# Altium schematic sheet power.SchDoc(power)
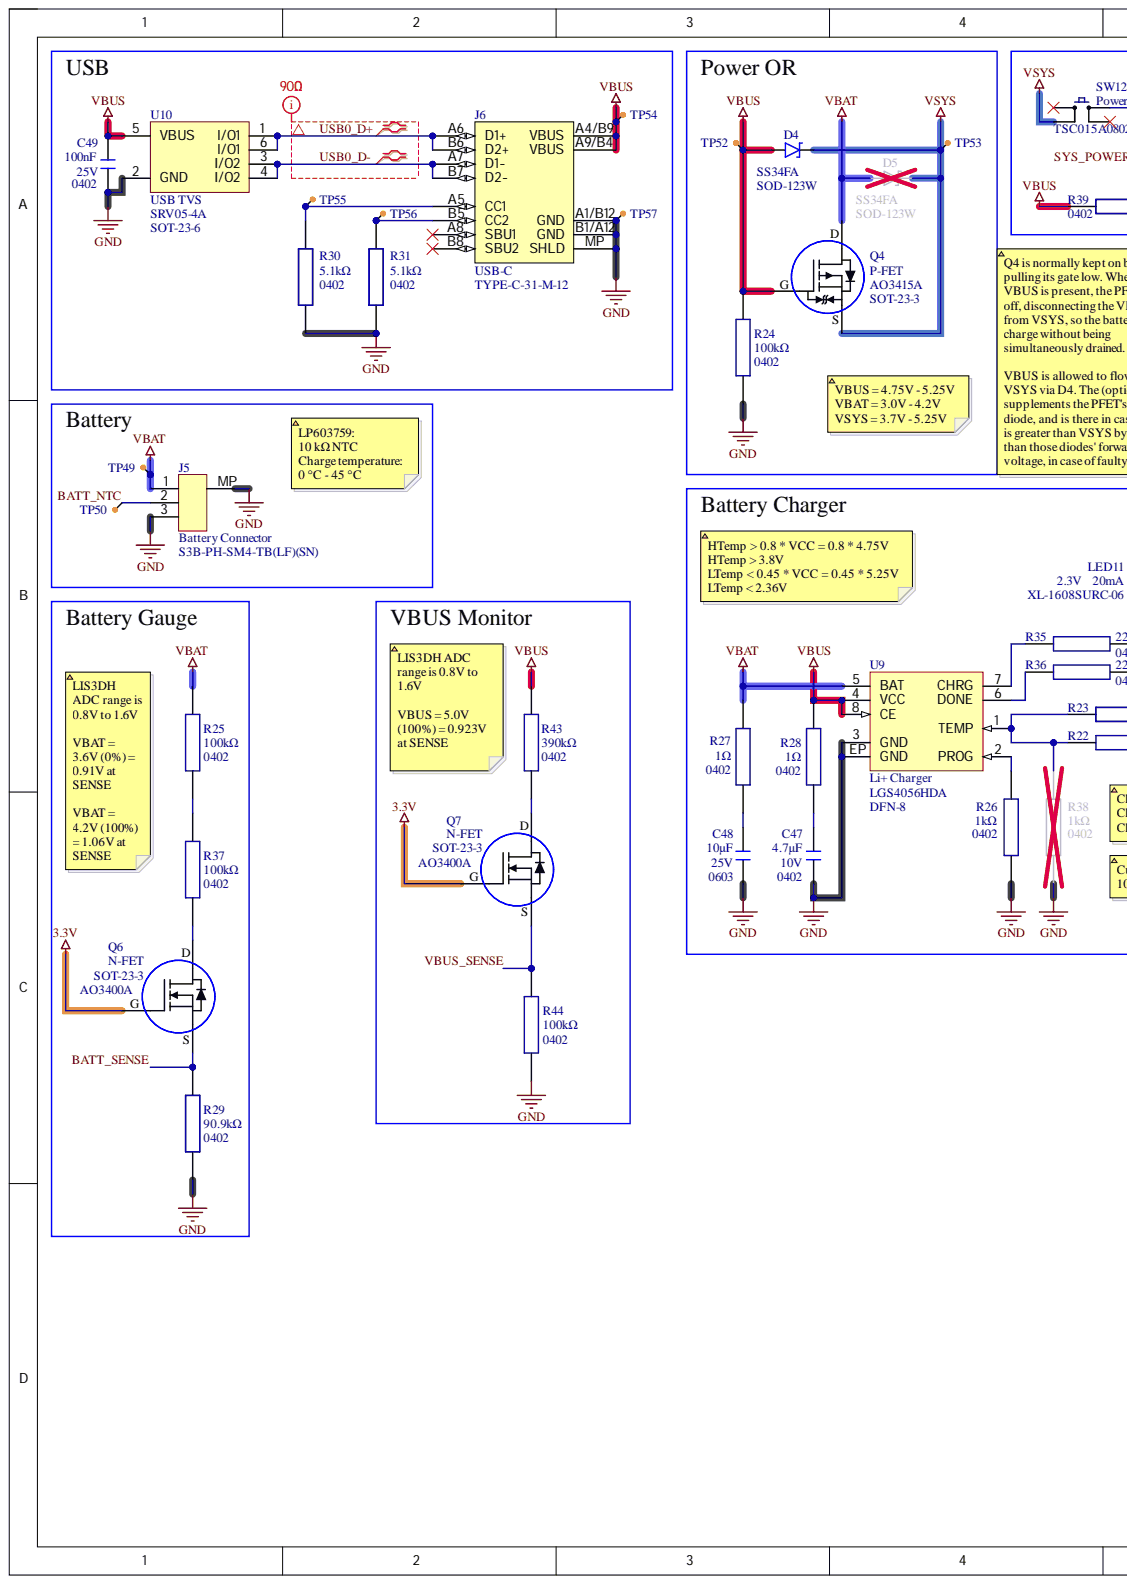
[diagram p0: power.SchDoc, left]
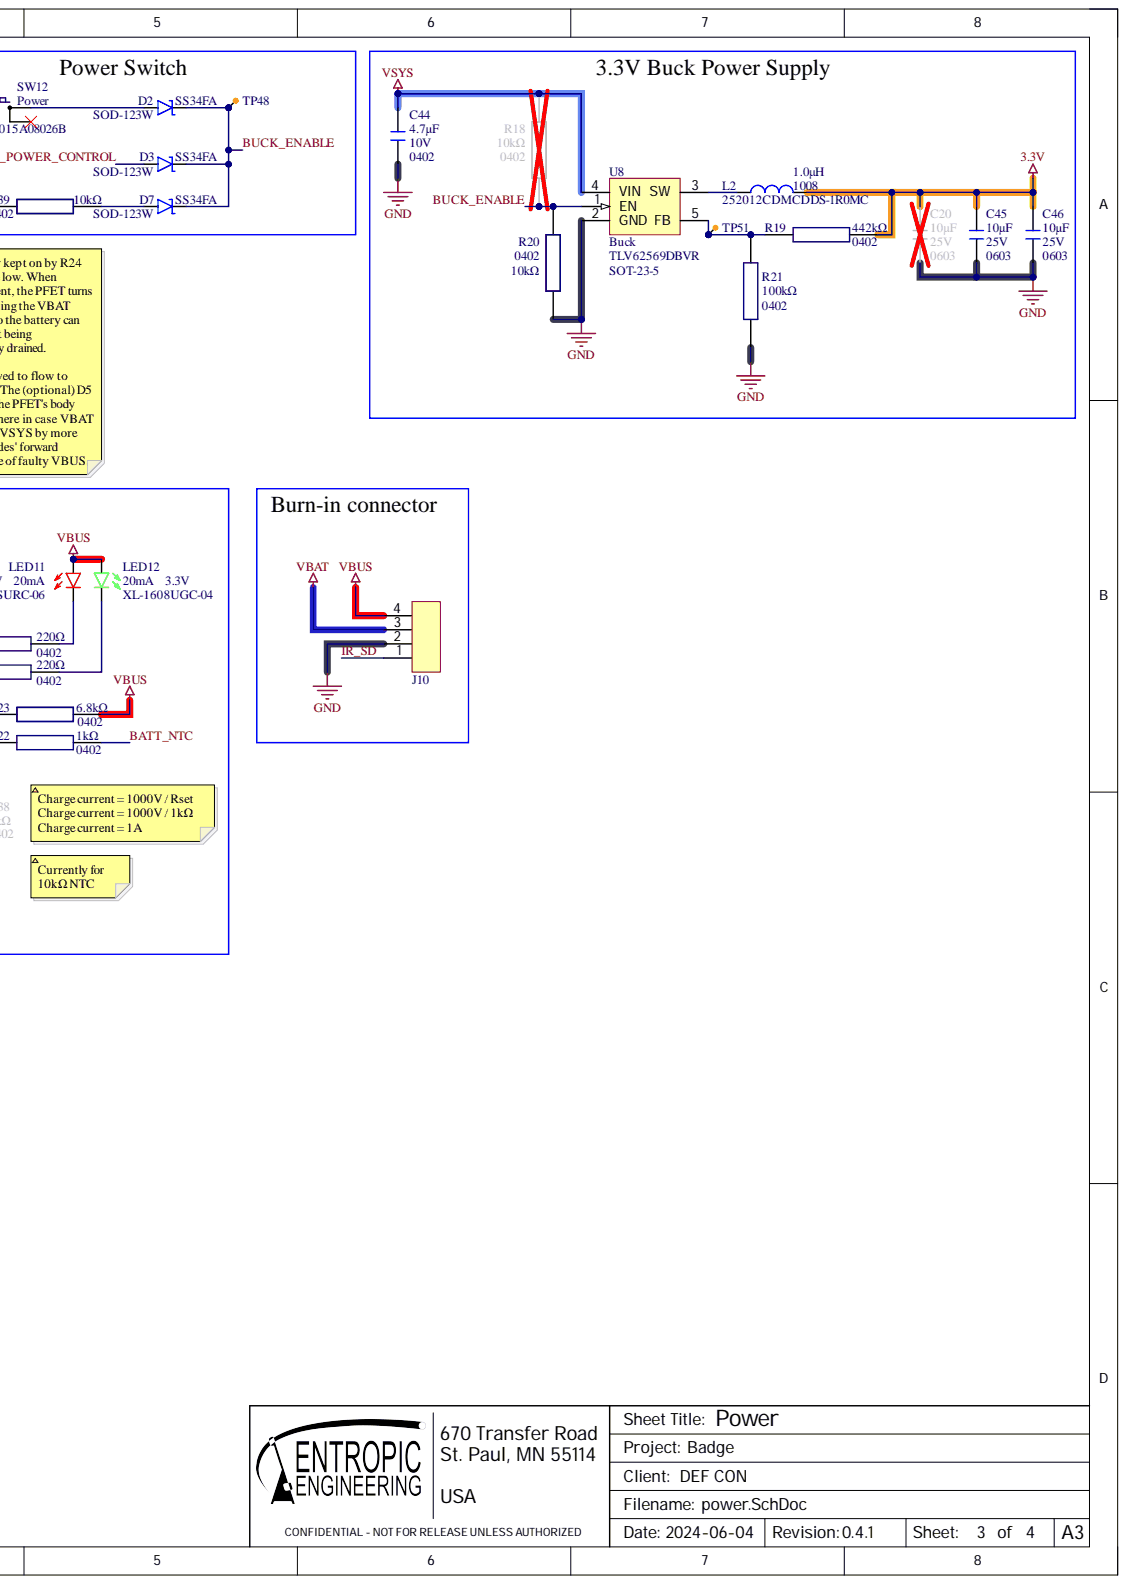
[diagram p1: power.SchDoc, right]

## Parts
C20  value 10µF  Case Code (Imperial): 0603  Voltage Rating: 25V  [0603_capacitor]  (hidden comment: CL10A106MA8NRNC)
C44  value 4.7µF  Case Code (Imperial): 0402  Voltage Rating: 10V  [0402_capacitor]  (hidden comment: CL05A475KP5NRNC)
C45  value 10µF  Case Code (Imperial): 0603  Voltage Rating: 25V  [0603_capacitor]  (hidden comment: CL10A106MA8NRNC)
C46  value 10µF  Case Code (Imperial): 0603  Voltage Rating: 25V  [0603_capacitor]  (hidden comment: CL10A106MA8NRNC)
C47  value 4.7µF  Case Code (Imperial): 0402  Voltage Rating: 10V  [0402_capacitor]  (hidden comment: CL05A475KP5NRNC)
C48  value 10µF  Case Code (Imperial): 0603  Voltage Rating: 25V  [0603_capacitor]  (hidden comment: CL10A106MA8NRNC)
C49  Capacitance: 100nF  Case Code (Imperial): 0402  Voltage: 25V  [0402_capacitor]  (hidden comment: GRM155R71E104KE14D)
D2  SS34FA  Case/Package: SOD-123W  [SOD-123]
D3  SS34FA  Case/Package: SOD-123W  [SOD-123]
D4  SS34FA  Case/Package: SOD-123W  [SOD-123]
D5  SS34FA  [SOD-123]
D7  SS34FA  Case/Package: SOD-123W  [SOD-123]
J5  Battery Connector  [S3B-PH-SM4-TB]
J6  USB-C  Manufacturer Part Number: TYPE-C-31-M-12  [USB_C_2.0_M12]
J10  BURN_IN  [BURN_IN_PADS]  (value not drawn)  (no BOM)
L2  252012CDMCDDS-1R0MC  value 1.0μH  Package: 1008  [INDC2520X12N]
LED11  XL-1608SURC-06  Current: 20mA  [0603_DIODE]
LED12  XL-1608UGC-04  Current: 20mA  [0603_DIODE]
Q4  P-FET  Case/Package: SOT-23-3  [SOT95P280X115-3N]
Q6  N-FET  Case/Package: SOT-23-3  [SOT95P280X115-3N]
Q7  N-FET  Case/Package: SOT-23-3  [SOT95P280X115-3N]
R18  value 10kΩ  Case Code (Imperial): 0402  [0402_resistor]  (hidden comment: RC0402FR-0710KL)
R19  value 442kΩ  Case Code (Imperial): 0402  [0402_resistor]  (hidden comment: RC0402FR-07442KL)
R20  value 10kΩ  Case Code (Imperial): 0402  [0402_resistor]  (hidden comment: RC0402FR-0710KL)
R21  value 100kΩ  Case Code (Imperial): 0402  [0402_resistor]  (hidden comment: RC0402FR-07100KL)
R22  value 1kΩ  Case Code (Imperial): 0402  [0402_resistor]  (hidden comment: RC0402JR-071KL)
R23  value 6.8kΩ  Case Code (Imperial): 0402  [0402_resistor]  (hidden comment: RC0402FR-076K8L)
R24  value 100kΩ  Case Code (Imperial): 0402  [0402_resistor]  (hidden comment: RC0402FR-07100KL)
R25  value 100kΩ  Case Code (Imperial): 0402  [0402_resistor]  (hidden comment: RC0402FR-07100KL)
R26  value 1kΩ  Case Code (Imperial): 0402  [0402_resistor]  (hidden comment: Rset)
R27  value 1Ω  Case/Package: 0402  [0402_resistor]  (hidden comment: 0402WGF100KTCE)
R28  value 1Ω  Case/Package: 0402  [0402_resistor]  (hidden comment: 0402WGF100KTCE)
R29  value 90.9kΩ  Case/Package: 0402  [0402_resistor]  (hidden comment: RC0402FR-0790K9L)
R30  value 5.1kΩ  Case Code (Imperial): 0402  [0402_resistor]  (hidden comment: RC0402FR-075K1L)
R31  value 5.1kΩ  Case Code (Imperial): 0402  [0402_resistor]  (hidden comment: RC0402FR-075K1L)
R35  value 220Ω  Case Code (Imperial): 0402  [0402_resistor]  (hidden comment: RC0402FR-07220RL)
R36  value 220Ω  Case Code (Imperial): 0402  [0402_resistor]  (hidden comment: RC0402FR-07220RL)
R37  value 100kΩ  Case Code (Imperial): 0402  [0402_resistor]  (hidden comment: RC0402FR-07100KL)
R38  value 1kΩ  Case Code (Imperial): 0402  [0402_resistor]  (hidden comment: Rset)
R39  value 10kΩ  Case Code (Imperial): 0402  [0402_resistor]  (hidden comment: RC0402FR-0710KL)
R43  value 390kΩ  Case/Package: 0402  [0402_resistor]  (hidden comment: RC0402FR-07390KL)
R44  value 100kΩ  Case Code (Imperial): 0402  [0402_resistor]  (hidden comment: RC0402FR-07100KL)
SW12  Power  [TSC015A08026B]
TP48  test_point-1  [test_point-1]  (value not drawn)  (no BOM)
TP49  test_point-1  [test_point-1]  (value not drawn)  (no BOM)
TP50  test_point-1  [test_point-1]  (value not drawn)  (no BOM)
TP51  test_point-1  [test_point-1]  (value not drawn)  (no BOM)
TP52  test_point-1  [test_point-1]  (value not drawn)  (no BOM)
TP53  test_point-1  [test_point-1]  (value not drawn)  (no BOM)
TP54  test_point-1  [test_point-1]  (value not drawn)  (no BOM)
TP55  test_point-1  [test_point-1]  (value not drawn)  (no BOM)
TP56  test_point-1  [test_point-1]  (value not drawn)  (no BOM)
TP57  test_point-1  [test_point-1]  (value not drawn)  (no BOM)
U8  Buck  Case/Package: SOT-23-5  [SOT95P280X115-5N]
U9  Li+ Charger  house_part_number: LGS4056HDA  Package: DFN-8  [SON50P200X80_HS-9N]
U10  USB TVS  Case/Package: SOT-23-6  Series: USB  [SOT95P280X110-6N]

## Nets
3.3V: C20.2 C45.2 C46.2 L2.2 Q6.1 Q7.1 R19.2
GND: C20.1 C44.1 C45.1 C46.1 C47.1 C48.1 C49.1 J5.3 J5.MP J6.A1/B12(GND) J6.B1/A12(GND) J6.MP(SHLD) J10.2 R20.1 R21.1 R24.1 R26.1 R29.2 R30.1 R31.1 R38.1 R44.1 TP57.1 U8.2(GND) U9.3(GND) U9.EP(GND) U10.2(GND)
VBAT: D5.A J5.1 J10.3 Q4.3 R25.2 R27.2 TP49.1 U9.5(BAT)
VBUS: C49.2 D4.A J6.A4/B9(VBUS) J6.A9/B4(VBUS) J10.4 LED11.2 LED12.2 Q4.1 R23.1 R24.2 R28.2 R39.2 R43.2 TP52.1 TP54.1 U9.4(VCC) U9.8(CE) U10.5(VBUS)
VSYS: C44.2 D4.K D5.K Q4.2 R18.2 SW12.2 TP53.1 U8.4(VIN)
BATT_NTC: J5.2 R22.1 TP50.1
BATT_SENSE: Q6.2 R29.1
BUCK_ENABLE: D2.K D3.K D7.K R18.1 R20.2 TP48.1 U8.1(EN)
IR_SD: J10.1
SYS_POWER_CONTROL: D3.A
USB0_D+: J6.A6(D1+) J6.B6(D2+) U10.1(I/O1) U10.6(I/O1)
USB0_D-: J6.A7(D1-) J6.B7(D2-) U10.3(I/O2) U10.4(I/O2)
VBUS_SENSE: Q7.2 R44.2
NetC47_2: C47.2 R28.1
NetC48_2: C48.2 R27.1
NetD2_A: D2.A SW12.3
NetD7_A: D7.A R39.1
NetJ6_A5: J6.A5(CC1) R30.2 TP55.1
NetJ6_B5: J6.B5(CC2) R31.2 TP56.1
NetL2_1: L2.1 U8.3(SW)
NetLED11_1: LED11.1 R35.1
NetLED12_1: LED12.1 R36.1
NetQ6_3: Q6.3 R37.1
NetQ7_3: Q7.3 R43.1
NetR19_1: R19.1 R21.2 TP51.1 U8.5(FB)
NetR22_2: R22.2 R23.2 R38.2 U9.1(TEMP)
NetR25_1: R25.1 R37.2
NetR26_2: R26.2 U9.2(PROG)
NetR35_2: R35.2 U9.7(CHRG)
NetR36_2: R36.2 U9.6(DONE)

Not connected: J6 A8(SBU1) B8(SBU2); SW12 1 4

## Library data of the parts
C20 C45 C46 C48 = CL10A106MA8NRNC: 10 µF, 25 V, X5R, 0603 Chip capacitor; house_part_number: C-10uF-0603-002; Manufacturer: Samsung; Material: 4241ConductorMaterial; Tolerance: 20%; Case Code (Metric): 1608; Case/Package: 0603; Category: Ceramic Capacitors; Component Kind: Standard; ComponentLink1Description: https://datasheet.ciiva.com/25766/cl10a106ma8nrnc-25766562.pdf?src-supplier=Digikey; ComponentLink1URL: https://datasheet.ciiva.com/25766/cl10a106ma8nrnc-25766562.pdf?src-supplier=Digikey; Height: 900um; Lead Free: Lead Free; Manufacturer Part Number: CL10A106MA8NRNC; Max Operating Temperature: 85°C; Min Operating Temperature: -55°C; Mounting Technology: Surface Mount; Packaging: Cut Tape; Pricing: 4000=0.0511, 8000=0.046, 12000=0.0434, 28000=0.0413, 100000=0.0356 (USD); RoHS: Yes; RoHS Compliant: Yes; Series: CL; Stock: 632000; Supplier 1: Arrow Electronics; Supplier Part Number 1: CL10A106MA8NRNC; Library Name: defcon_badge.SchLib
C44 C47 = CL05A475KP5NRNC: 4.7 µF, 10 V, X5R, 0402 Chip capacitor; house_part_number: C-4.7uF-0402-002; Manufacturer: Samsung; Material: Ceramic; Tolerance: 10%; Case Code (Metric): 1005; Case/Package: 0402; Category: Ceramic Capacitors; Component Kind: Standard; ComponentLink1Description: https://datasheet.ciiva.com/25724/cl05a475kp5nrnc-25724404.pdf?src-supplier=Digikey; ComponentLink1URL: https://datasheet.ciiva.com/25724/cl05a475kp5nrnc-25724404.pdf?src-supplier=Digikey; ComponentLink2Description: https://datasheet.ciiva.com/29198/458873-da-01-en-vs-kondensator-0402-29198304.pdf?src-supplier=Conrad; ComponentLink2URL: https://datasheet.ciiva.com/29198/458873-da-01-en-vs-kondensator-0402-29198304.pdf?src-supplier=Conrad; Construction: Flat; Depth: 0.5mm; Dielectric: X5R; Height: 0.5mm; Lead Free: Lead Free; Length: 1mm; Manufacturer Part Number: CL05A475KP5NRNC; Max Operating Temperature: 85°C; Min Operating Temperature: -55°C; Mounting Technology: Surface Mount; Package Quantity: 1; Packaging: Tape and Reel; Pricing: 1=0.28, 10=0.184, 50=0.129, 100=0.1042, 500=0.0774, 1000=0.06772, 2500=0.0645, 5000=0.05966 (USD); Radiation Hardening: No; RoHS: Yes; RoHS Compliant: Yes; Series: CL; Stock: 606274; Supplier 1: Digikey; Supplier Part Number 1: 1276-1480-1-ND; Thickness: 0.022inch; Width: 0.02inch; Library Name: defcon_badge.SchLib
C49 = GRM155R71E104KE14D: 100 nF, 25 V, X7R, 0402 Chip capacitor; house_part_number: C-100nF-0402-002; Manufacturer: Murata; Dielectric Material: Ceramic; Tolerance: 10%; Case Code (Metric): 1005; Case/Package: 0402; Category: Ceramic Capacitors; Component Kind: Standard; ComponentLink1Description: http://www.farnell.com/datasheets/1872206.pdf?src-supplier=Farnell; ComponentLink1URL: http://www.farnell.com/datasheets/1872206.pdf?src-supplier=Farnell; ComponentLink2Description: http://components.arrow.com/part/dataview/0323_06361249?src-supplier=Arrow+Europe; ComponentLink2URL: http://components.arrow.com/part/dataview/0323_06361249?src-supplier=Arrow+Europe; ComponentLink3Description: http://uk.rs-online.com/webdocs/144c/0900766b8144c113.pdf?src-supplier=RSComponents; ComponentLink3URL: http://uk.rs-online.com/webdocs/144c/0900766b8144c113.pdf?src-supplier=RSComponents; ComponentLink4Description: http://www.farnell.com/datasheets/2048008.pdf?src-supplier=Farnell; ComponentLink4URL: http://www.farnell.com/datasheets/2048008.pdf?src-supplier=Farnell; ComponentLink5Description: http://www.mouser.com/ds/2/281/c02e-2905.pdf?src-supplier=Mouser; ComponentLink5URL: http://www.mouser.com/ds/2/281/c02e-2905.pdf?src-supplier=Mouser; ComponentLink6Description: http://www.farnell.com/datasheets/2048008.pdf?src-supplier=Newark; ComponentLink6URL: http://www.farnell.com/datasheets/2048008.pdf?src-supplier=Newark; ComponentLink7Description: https://search.murata.co.jp/Ceramy/image/img/A01X/G101/ENG/GRM155R71E104KE14-01.pdf?src-supplier=Digi-Key; ComponentLink7URL: https://search.murata.co.jp/Ceramy/image/img/A01X/G101/ENG/GRM155R71E104KE14-01.pdf?src-supplier=Digi-Key; ComponentLink8Description: http://www.farnell.com/datasheets/2048008.pdf?src-supplier=Element14; ComponentLink8URL: http://www.farnell.com/datasheets/2048008.pdf?src-supplier=Element14; ComponentLink9Description: http://psearch.en.murata.com/capacitor/product/GRM155R71E104KE14%23.pdf?src-supplier=Avnet; ComponentLink9URL: http://psearch.en.murata.com/capacitor/product/GRM155R71E104KE14%23.pdf?src-supplier=Avnet; Depth: 0.5mm; Dielectric: X7R; Height: 0.5mm; Lead Free: Lead Free; Length: 1mm; Manufacturer Part Number: GRM155R71E104KE14D; Max Operating Temperature: 125°C; Min Operating Temperature: -55°C; Mount: Surface Mount; Package Quantity: 10000; Packaging: Tape and Reel; Pricing 1: 1=0.10000, 10=0.02700, 50=0.01460, 100=0.01190, 500=0.00848, 1000=0.00666, 2500=0.00605, 5000=0.00557 (USD); REACH SVHC: Unknown; Resistor Type: Ceramic; RoHS: Yes; RoHS Compliant: Yes; Supplier 1: Digi-Key; Supplier Part Number 1: 490-10698-1-ND; Termination: SMD/SMT; Thickness: 0.022inch; Voltage Rating: 25V; Voltage Rating (DC): 25V; Weight: 0.000212oz; Width: 0.02inch; Library Name: defcon_badge.SchLib
D2-D5 D7 = SS34FA: 40 V, 3 A Schottky Rectifier, SOD-123FA; house_part_number: D-SCHOTTKY-3A-SOD123F-002; Manufacturer: ON Semiconductor / Fairchild; Average Rectified Current: 3A; Element Configuration: Single; Max Forward Surge Current (Ifsm): 80A; Max Repetitive Reverse Voltage (Vrrm): 40V; Category: Schottky Diodes; Component Kind: Standard; ComponentLink1Description: http://www.farnell.com/datasheets/2552647.pdf?src-supplier=Farnell; ComponentLink1URL: http://www.farnell.com/datasheets/2552647.pdf?src-supplier=Farnell; ComponentLink2Description: http://www.mouser.com/ds/2/149/SS34FA-1012641.pdf?src-supplier=Mouser; ComponentLink2URL: http://www.mouser.com/ds/2/149/SS34FA-1012641.pdf?src-supplier=Mouser; ComponentLink3Description: http://www.farnell.com/datasheets/2552647.pdf?src-supplier=Element14; ComponentLink3URL: http://www.farnell.com/datasheets/2552647.pdf?src-supplier=Element14; ComponentLink4Description: http://www.farnell.com/datasheets/2552647.pdf?src-supplier=Newark; ComponentLink4URL: http://www.farnell.com/datasheets/2552647.pdf?src-supplier=Newark; ComponentLink5Description: https://www.fairchildsemi.com/datasheets/SS/SS34FA.pdf?src-supplier=Digikey; ComponentLink5URL: https://www.fairchildsemi.com/datasheets/SS/SS34FA.pdf?src-supplier=Digikey; ComponentLink6Description: https://www.onsemi.com/pub/Collateral/SS36FA-D.PDF?src-supplier=Digikey; ComponentLink6URL: https://www.onsemi.com/pub/Collateral/SS36FA-D.PDF?src-supplier=Digikey; ComponentLink7Description: https://datasheet.octopart.com/SS34FA-ON-Semiconductor-datasheet-137713515.pdf?src-supplier=TME; ComponentLink7URL: https://datasheet.octopart.com/SS34FA-ON-Semiconductor-datasheet-137713515.pdf?src-supplier=TME; Manufacturer Part Number: SS34FA; Max Reverse Voltage (DC): 40V; Mounting Technology: Surface Mount; Packaging: Cut Tape; Pricing: 1=0.469, 25=0.4, 50=0.341, 100=0.281, 250=0.251 (USD); RoHS: Yes; RoHS Compliant: Yes; Series: Automotive, AEC-Q101; Stock: 2402; Supplier: Avnet; Supplier 1: LCSC; Supplier Part Number: 01AC9736; Supplier Part Number 1: C719833; Weight: 0.019g; Library Name: defcon_badge.SchLib
  D5: Case/Package: SOD-123W
J5 = S3B-PH-SM4-TB(LF)(SN): 3-Pin JST-PH connector, 2mm pitch, right-angle SMD; Manufacturer: JST; Connector Type: Header; Contact Resistance: 10mR; Current Rating: 2A; Gender: Male; Insulation Resistance: 1GR; Max Voltage Rating (AC): 100V; Number of Contacts: 3; Category: Headers and Wire Housings; Color: Natural,White; Component Kind: Standard; ComponentLink1Description: https://datasheet.ciiva.com/11748/0900766b80df0d9d-11748720.pdf?src-supplier=Rs; ComponentLink1URL: https://datasheet.ciiva.com/11748/0900766b80df0d9d-11748720.pdf?src-supplier=Rs; ComponentLink2Description: https://datasheet.ciiva.com/712/eph-712555.pdf?src-supplier=Digikey; ComponentLink2URL: https://datasheet.ciiva.com/712/eph-712555.pdf?src-supplier=Digikey; ComponentLink3Description: https://datasheet.ciiva.com/712/eph-712554.pdf?src-supplier=Digikey; ComponentLink3URL: https://datasheet.ciiva.com/712/eph-712554.pdf?src-supplier=Digikey; ComponentLink4Description: https://datasheet.ciiva.com/712/eph-712556.pdf?src-supplier=Digikey; ComponentLink4URL: https://datasheet.ciiva.com/712/eph-712556.pdf?src-supplier=Digikey; ComponentLink5Description: https://datasheet.ciiva.com/8052/80594-8052219.pdf?src-supplier=Element14; ComponentLink5URL: https://datasheet.ciiva.com/8052/80594-8052219.pdf?src-supplier=Element14; ComponentLink6Description: https://datasheet.ciiva.com/22693/80594-22693485.pdf?src-supplier=Element14; ComponentLink6URL: https://datasheet.ciiva.com/22693/80594-22693485.pdf?src-supplier=Element14; Contact Finish - Mating: TIN; Contact Material: Copper Alloy,Tin,Bronze,Brass; Contact Mating Length: 0.13inch; Contact Plating: Tin,Copper; Contact Shape: Square; Contact Type: Male Pin; Depth: 7.6mm; Fastening Type: Detent; Flammability Rating: UL94 V-0; Height: 9.4mm; house_part_number: S3B-PH-SM4-TB(LF)(SN); Housing Color: Beige; Housing Material: Polyamide; Insulation Color: Natural; Insulation Material: Nylon; Lead Free: 5697LeadFree; Lead Pitch: 2mm; Length: 9.9mm; Manufacturer Part Number: S3B-PH-SM4-TB(LF)(SN); Material: Polyamide; Max Current Rating: 2A; Max Operating Temperature: 85°C; Max Voltage Rating (DC): 100V; Min Operating Temperature: -25°C; Mounting Technology: Surface Mount; Number of Poles: 3; Number of Positions: 3; Number of Rows: 1; Orientation: Right Angle; Packaging: Tape and Reel; Pitch: 2mm; Pricing 1: 5=0.223, 50=0.1765, 150=0.1538, 1000=0.1202, 2000=0.1152, 5000=0.1122 (USD); Reach SVHC Compliant: No; RoHS: Yes; Series: PH; Stock 1: 30540; Supplier 1: LCSC; Supplier Part Number 1: C265101; Termination: Solder; Voltage Rating: 100V; Voltage Rating (AC): 100V; Voltage Rating (DC): 100V; Weight: 28.349523g; Width: 5mm; Working Voltage: 100V; Library Name: defcon_badge.SchLib
J6 = USB4105-GF-A: USB-C 2.0 Female Connector; Alternate Manufacturer: Korean HROparts Elec; Manufacturer: Global Connector Technology; Connector Type: USB; Gender: Receptacle; Mating Cycles: 10000; Number of Ports: 1; Shielding: Shielded; Alternate Part Number: USB4105-GF-A; Category: USB Connectors; Component Kind: Standard; ComponentLink1Description: https://gct.co/files/drawings/usb4105.pdf?src-supplier=Digi-Key; ComponentLink1URL: https://gct.co/files/drawings/usb4105.pdf?src-supplier=Digi-Key; Contact Plating: Gold; Flammability Rating: UL94 V-0; house_part_number: USB_C_2.0_M12; Insulation Color: Black; Max Operating Temperature: 85°C; Min Operating Temperature: -40°C; Mounting Technology: Surface Mount,Through Hole,Horizontal; Pricing: 1=1, 20=3.07, 60=3.02, 160=2.64, 400=2.63 (EUR); RoHS: No; Series: USB4105; Stock: 1499; Supplier 1: Farnell; Supplier Part Number 1: 3588205; Termination: Solder; Library Name: defcon_badge.SchLib
J10 = BURN_IN: pickable: no; Library Name: defcon_badge.SchLib
L2 = 252012CDMCDDS-1R0MC: 1 μH, 4.2 A, 1008 Inductor; house_part_number: L-1uH-1008-002; Manufacturer: Sumida; Category: Fixed Inductors; Component Kind: Standard; Manufacturer Part Number: 252012CDMCDDS-1R0MC; Pricing: 1=0.55, 10=0.473, 25=0.434, 50=0.3944, 100=0.3511, 250=0.33132, 500=0.284, 1000=0.25245 (USD); RoHS: No; Stock: 45912; Supplier: Digikey; Supplier Part Number 1: 308-2603-1-ND; Library Name: defcon_badge.SchLib
LED11 = XL-1608SURC-06: Red LED, 1.9 - 2.3 V, 20 mA, 0603; Manufacturer: XINGLIGHT; Forward Voltage: 2.3V; Component Kind: Standard; house_part_number: XL-1608SURC-06; Manufacturer Part Number: XL-1608SURC-06; Pricing 1: 100=0.0029, 1000=0.0022, 4000=0.0019, 8000=0.0017, 48000=0.0015 (USD); RoHS: No; Stock 1: 3172200; Supplier 1: LCSC; Supplier Part Number 1: C965799; Library Name: defcon_badge.SchLib
LED12 = XL-1608UGC-04: Green LED, 2.7 - 3.3 V, 20 mA, 0603; Manufacturer: XINGLIGHT; Forward Voltage: 3.3V; Component Kind: Standard; house_part_number: XL-1608UGC-04; Manufacturer Part Number: XL-1608UGC-04; Pricing 1: 100=0.0042, 1000=0.0033, 4000=0.0027, 8000=0.0024, 48000=0.0023, 100000=0.0022 (USD); RoHS: No; Stock 1: 2830700; Supplier 1: LCSC; Supplier Part Number 1: C965804; Library Name: defcon_badge.SchLib
Q4 = AO3415A: P-FET, 30V, 6A, SOT-23-3; Manufacturer: Alpha & Omega Semiconductor; Continuous Drain Current (ID): 5A; Drain to Source Voltage (Vdss): 20V; Input Capacitance: 940pF; Max Power Dissipation: 1.5W; Rds On Max: 45mΩ; Threshold Voltage: 8mV; Turn-Off Delay Time: 19ps; Turn-On Delay Time: 13ps; Category: MOSFETs; Component Kind: Standard; ComponentLink1Description: https://datasheet.ciiva.com/940/ao3415-940752.pdf?src-supplier=Digikey; ComponentLink1URL: https://datasheet.ciiva.com/940/ao3415-940752.pdf?src-supplier=Digikey; ComponentLink2Description: https://datasheet.ciiva.com/940/ao3415-940753.pdf?src-supplier=Digikey; ComponentLink2URL: https://datasheet.ciiva.com/940/ao3415-940753.pdf?src-supplier=Digikey; house_part_number: AO3415A; Manufacturer Part Number: AO3415A; Max Operating Temperature: 150°C; Min Operating Temperature: -55°C; Mounting Technology: Surface Mount; Packaging: Cut Tape; Pins: 3; Pricing: 3000=0.3033, 6000=0.3003, 9000=0.2972, 15000=0.2942, 24000=0.2912, 30000=0.2881 (USD); RoHS: Yes; RoHS Compliant: Yes; Series: -; Stock: 21000; Supplier: Arrow Electronics; Supplier 1: LCSC; Supplier Part Number: AO3415A; Supplier Part Number 1: C133233; Library Name: defcon_badge.SchLib
Q6 Q7 = AO3400A: N-FET, 30V, 5.7A, SOT-23-3; Manufacturer: Alpha & Omega Semiconductor; Drain to Source Resistance: 18mR; Input Capacitance: 630pF; Max Power Dissipation: 1.4W; Number of Channels: 1NUMBER; Rds On Max: 26.5mR; Threshold Voltage: 650mV; Turn-Off Delay Time: 25ns; Turn-On Delay Time: 3ns; Category: MOSFETs; Component Kind: Standard; ComponentLink1Description: https://datasheet.ciiva.com/940/ao3400a-940739.pdf?src-supplier=Digikey; ComponentLink1URL: https://datasheet.ciiva.com/940/ao3400a-940739.pdf?src-supplier=Digikey; ComponentLink2Description: https://datasheet.ciiva.com/26906/getdatasheetpartid-64438-26906526.pdf?src-supplier=Verical; ComponentLink2URL: https://datasheet.ciiva.com/26906/getdatasheetpartid-64438-26906526.pdf?src-supplier=Verical; ComponentLink3Description: https://datasheet.ciiva.com/940/ao3400a-940740.pdf?src-supplier=Digikey; ComponentLink3URL: https://datasheet.ciiva.com/940/ao3400a-940740.pdf?src-supplier=Digikey; ComponentLink4Description: https://datasheet.ciiva.com/940/ao3400a-940741.pdf?src-supplier=Digikey; ComponentLink4URL: https://datasheet.ciiva.com/940/ao3400a-940741.pdf?src-supplier=Digikey; Continuous Drain Current (ID): 5.7A; Drain to Source Breakdown Voltage: 30V; Drain to Source Voltage (Vdss): 30V; Height: 1.25mm; house_part_number: AO3400A; Lead Free: Lead Free; Manufacturer Part Number: AO3400A; Max Operating Temperature: 150°C; Min Operating Temperature: -55°C; Mounting Technology: Surface Mount; Packaging: Cut Tape; Pricing: 3000=0.0899, 6000=0.0869, 9000=0.0763, 30000=0.0746, 75000=0.0627 (USD); RoHS: Yes; RoHS Compliant: Yes; Series: -; Stock: 141000; Supplier: Arrow Electronics; Supplier Part Number 1: AO3400A; Library Name: defcon_badge.SchLib
R18 R20 R39 = RC0402FR-0710KL: 10 kΩ, 0402 Chip resistor; house_part_number: R-10k-0402-1%-001; Manufacturer: Yageo; Max Power Dissipation: 63mW; Power Rating: 62.5mW; Resistance Tolerance: 1%; Temperature Coefficient: 100ppm/°C; Voltage Rating: 50V; Case Code (Metric): 1005; Case/Package: 0402; Category: Chip SMD Resistors; Component Kind: Standard; ComponentLink1Description: https://datasheet.ciiva.com/12667/pyu-rc0402-51-rohs-l-6-r-12667067.pdf?src-supplier=Mouser; ComponentLink1URL: https://datasheet.ciiva.com/12667/pyu-rc0402-51-rohs-l-6-r-12667067.pdf?src-supplier=Mouser; Construction: Rectangular; Depth: 0.5mm; Features: Moisture Resistant; Lead Free: 5697LeadFree; Lead Pitch: 0.2mm; Length: 1mm; Manufacturer Part Number: RC0402FR-0710KL; Max Operating Temperature: 155°C; Military Standard: Not; Min Operating Temperature: -55°C; Number of Terminations: 2; Packaging: Tape and Reel; Pins: 2; Pricing: 1=0.1, 10=0.015, 50=0.0088, 100=0.0073, 500=0.00488, 1000=0.00417, 5000=0.00297 (USD); Reach SVHC Compliant: Unknown; Resistor Type: Thick Film; RoHS: Yes; RoHS Compliant: Yes; Series: RC; Stock: 9380606; Supplier 1: Digikey; Supplier Part Number 1: 311-10.0KLRCT-ND; Termination: SMD/SMT; Tolerance: 1%; Voltage Rating (DC): 50V; Width: 0.5mm; Library Name: defcon_badge.SchLib
R19 = RC0402FR-07442KL: 442 kΩ, 0402 Chip resistor; house_part_number: R-442k-0402-1%-001; Manufacturer: Yageo; Max Power Dissipation: 63mW; Power Rating: 62.5mW; Temperature Coefficient: 100ppm/°C; Tolerance: 1%; Voltage Rating: 50V; Case Code (Metric): 1005; Case/Package: 0402; Category: Chip SMD Resistors; Component Kind: Standard; ComponentLink1Description: https://datasheet.ciiva.com/2453/pyu-r-int-thick-7-2453668.pdf?src-supplier=Digikey; ComponentLink1URL: https://datasheet.ciiva.com/2453/pyu-r-int-thick-7-2453668.pdf?src-supplier=Digikey; ComponentLink2Description: https://datasheet.ciiva.com/2453/pyu-r-int-thick-7-2453667.pdf?src-supplier=Digikey; ComponentLink2URL: https://datasheet.ciiva.com/2453/pyu-r-int-thick-7-2453667.pdf?src-supplier=Digikey; ComponentLink3Description: https://datasheet.ciiva.com/2453/pyu-r-int-thick-7-2453669.pdf?src-supplier=Digikey; ComponentLink3URL: https://datasheet.ciiva.com/2453/pyu-r-int-thick-7-2453669.pdf?src-supplier=Digikey; ComponentLink4Description: https://datasheet.ciiva.com/8885/pyu-rc0402-51-rohs-l-6-8885693.pdf?src-supplier=Avnet; ComponentLink4URL: https://datasheet.ciiva.com/8885/pyu-rc0402-51-rohs-l-6-8885693.pdf?src-supplier=Avnet; Construction: Rectangular; Contact Plating: Tin,Lead; Depth: 0.5mm; Features: Moisture Resistant; Height: 0.016inch; Length: 1mm; Manufacturer Part Number: RC0402FR-07442KL; Max Operating Temperature: 155°C; Military Standard: Not; Min Operating Temperature: -55°C; Number of Terminations: 2; Package Quantity: 1; Packaging: Tape and Reel; Pricing: 10000=0.0012, 50000=0.0011, 250000=0.001, 500000=0.001, 1500000=0.0009 (USD); RoHS: Yes; RoHS Compliant: Yes; Series: RC; Stock: 40000; Supplier 1: Future Electronics; Supplier Part Number 1: 1075035; Termination: SMD/SMT; Voltage Rating (DC): 50V; Width: 0.02inch; Library Name: defcon_badge.SchLib
R21 R24 R25 R37 R44 = RC0402FR-07100KL: 100 kΩ, 0402 Chip resistor; house_part_number: R-100k-0402-1%-001; Manufacturer: Yageo; Max Power Dissipation: 63mW; Resistance Tolerance: 1%; Temperature Coefficient: 100ppm/°C; Voltage Rating: 50V; Case Code (Metric): 1005; Case/Package: 0402; Category: Chip SMD Resistors; Component Kind: Standard; ComponentLink1Description: https://datasheet.ciiva.com/11819/0900766b80c66297-11819452.pdf?src-supplier=Rs; ComponentLink1URL: https://datasheet.ciiva.com/11819/0900766b80c66297-11819452.pdf?src-supplier=Rs; ComponentLink2Description: https://datasheet.ciiva.com/22747/1018594-22747509.pdf?src-supplier=Element14; ComponentLink2URL: https://datasheet.ciiva.com/22747/1018594-22747509.pdf?src-supplier=Element14; ComponentLink3Description: https://datasheet.ciiva.com/2453/pyu-r-int-thick-7-2453362.pdf?src-supplier=Digikey; ComponentLink3URL: https://datasheet.ciiva.com/2453/pyu-r-int-thick-7-2453362.pdf?src-supplier=Digikey; ComponentLink4Description: https://datasheet.ciiva.com/12667/pyu-rc0402-51-rohs-l-6-r-12667930.pdf?src-supplier=Mouser; ComponentLink4URL: https://datasheet.ciiva.com/12667/pyu-rc0402-51-rohs-l-6-r-12667930.pdf?src-supplier=Mouser; ComponentLink5Description: https://datasheet.ciiva.com/15189/getdatasheetpartid-323696-15189334.pdf?src-supplier=Verical; ComponentLink5URL: https://datasheet.ciiva.com/15189/getdatasheetpartid-323696-15189334.pdf?src-supplier=Verical; ComponentLink6Description: https://datasheet.ciiva.com/2453/pyu-r-int-thick-7-2453363.pdf?src-supplier=Digikey; ComponentLink6URL: https://datasheet.ciiva.com/2453/pyu-r-int-thick-7-2453363.pdf?src-supplier=Digikey; ComponentLink7Description: https://datasheet.ciiva.com/2453/pyu-r-int-thick-7-2453361.pdf?src-supplier=Digikey; ComponentLink7URL: https://datasheet.ciiva.com/2453/pyu-r-int-thick-7-2453361.pdf?src-supplier=Digikey; Construction: Rectangular; Depth: 0.5mm; Features: Moisture Resistant; Height: 0.016inch; Lead Free: 5697LeadFree; Length: 0.039inch; Manufacturer Part Number: RC0402FR-07100KL; Max Operating Temperature: 155°C; Military Standard: Not; Min Operating Temperature: -55°C; Number of Terminations: 2; Package Quantity: 1; Packaging: Tape and Reel; Power Rating: 63mW; Pricing: 10000=0.0012, 50000=0.0011, 100000=0.0011, 250000=0.001, 300000=0.001, 500000=0.001, 750000=0.001, 1500000=0.0009, 2000000=0.0009 (USD); Reach SVHC Compliant: No; Resistor Type: Thick Film; RoHS: Yes; RoHS Compliant: Yes; Series: RC; Stock: 44340000; Supplier 1: Future Electronics; Supplier Part Number 1: 6468466; Technology: THICK FILM; Termination: SMD/SMT; Tolerance: 1%; Voltage Rating (AC): 50V; Width: 0.02inch; Library Name: defcon_badge.SchLib
R22 R26 R38 = RC0402JR-071KL: 1 kΩ, 0402 Chip resistor; house_part_number: R-1k-0402-1%-001; Manufacturer: Yageo; Max Power Dissipation: 63mW; Power Rating: 62.5mW; Resistance Tolerance: 5%; Temperature Coefficient: 100ppm/°C; Voltage Rating: 50V; Case Code (Metric): 1005; Case/Package: 0402; Category: Chip SMD Resistors; Component Kind: Standard; ComponentLink1Description: https://datasheet.ciiva.com/7983/1018594-7983558.pdf?src-supplier=Element14; ComponentLink1URL: https://datasheet.ciiva.com/7983/1018594-7983558.pdf?src-supplier=Element14; ComponentLink2Description: https://datasheet.ciiva.com/2452/pyu-r-int-thick-7-2452756.pdf?src-supplier=Digikey; ComponentLink2URL: https://datasheet.ciiva.com/2452/pyu-r-int-thick-7-2452756.pdf?src-supplier=Digikey; ComponentLink3Description: https://datasheet.ciiva.com/2452/pyu-r-int-thick-7-2452757.pdf?src-supplier=Digikey; ComponentLink3URL: https://datasheet.ciiva.com/2452/pyu-r-int-thick-7-2452757.pdf?src-supplier=Digikey; ComponentLink4Description: https://datasheet.ciiva.com/2452/pyu-r-int-thick-7-2452755.pdf?src-supplier=Digikey; ComponentLink4URL: https://datasheet.ciiva.com/2452/pyu-r-int-thick-7-2452755.pdf?src-supplier=Digikey; ComponentLink5Description: https://datasheet.ciiva.com/7850/1018594-7850170.pdf?src-supplier=Element14; ComponentLink5URL: https://datasheet.ciiva.com/7850/1018594-7850170.pdf?src-supplier=Element14; ComponentLink6Description: https://datasheet.ciiva.com/7850/1018594-7850171.pdf?src-supplier=Element14; ComponentLink6URL: https://datasheet.ciiva.com/7850/1018594-7850171.pdf?src-supplier=Element14; ComponentLink7Description: https://datasheet.ciiva.com/17081/pyu-rc0402-51-rohs-l-6-r-17081438.pdf?src-supplier=Future+Electronics; ComponentLink7URL: https://datasheet.ciiva.com/17081/pyu-rc0402-51-rohs-l-6-r-17081438.pdf?src-supplier=Future+Electronics; Features: Moisture Resistant; Height: 0.016inch; Lead Free: 5697LeadFree; Length: 0.039inch; Manufacturer Part Number: RC0402JR-071KL; Max Operating Temperature: 155°C; Min Operating Temperature: -55°C; Number of Terminations: 2; Package Quantity: 1; Packaging: Cut Tape; Pricing: 1=0.1, 10=0.018, 50=0.0102, 100=0.0083, 500=0.00528, 1000=0.00444, 5000=0.00307 (USD); Reach SVHC Compliant: No; Resistor Type: Thick Film; RoHS: Yes; RoHS Compliant: Yes; Series: RC; Stock: 15490862; Supplier 1: Digikey; Supplier Part Number 1: 311-1.0KJRCT-ND; Termination: SMD/SMT; Tolerance: 5%; Voltage Rating (AC): 50V; Width: 0.02inch; Library Name: defcon_badge.SchLib
R23 = RC0402FR-076K8L: 6.8 kΩ, 0402 Chip resistor; house_part_number: R-6.8k-0402-1%-001; Manufacturer: Yageo; Max Power Dissipation: 63mW; Resistance Tolerance: 1%; Temperature Coefficient: 100ppm/°C; Voltage Rating: 50V; Case Code (Metric): 1005; Case/Package: 0402; Category: Chip SMD Resistors; Component Kind: Standard; ComponentLink1Description: https://datasheet.ciiva.com/11819/0900766b80c66297-11819535.pdf?src-supplier=Rs; ComponentLink1URL: https://datasheet.ciiva.com/11819/0900766b80c66297-11819535.pdf?src-supplier=Rs; ComponentLink2Description: https://datasheet.ciiva.com/2453/pyu-r-int-thick-7-2453500.pdf?src-supplier=Digikey; ComponentLink2URL: https://datasheet.ciiva.com/2453/pyu-r-int-thick-7-2453500.pdf?src-supplier=Digikey; ComponentLink3Description: https://datasheet.ciiva.com/2453/pyu-r-int-thick-7-2453501.pdf?src-supplier=Digikey; ComponentLink3URL: https://datasheet.ciiva.com/2453/pyu-r-int-thick-7-2453501.pdf?src-supplier=Digikey; ComponentLink4Description: https://datasheet.ciiva.com/2453/pyu-r-int-thick-7-2453499.pdf?src-supplier=Digikey; ComponentLink4URL: https://datasheet.ciiva.com/2453/pyu-r-int-thick-7-2453499.pdf?src-supplier=Digikey; ComponentLink5Description: https://datasheet.ciiva.com/12668/pyu-rc0402-51-rohs-l-6-r-12668201.pdf?src-supplier=Mouser; ComponentLink5URL: https://datasheet.ciiva.com/12668/pyu-rc0402-51-rohs-l-6-r-12668201.pdf?src-supplier=Mouser; ComponentLink6Description: https://datasheet.ciiva.com/7850/1018594-7850078.pdf?src-supplier=Element14; ComponentLink6URL: https://datasheet.ciiva.com/7850/1018594-7850078.pdf?src-supplier=Element14; ComponentLink7Description: https://datasheet.ciiva.com/7850/1018594-7850079.pdf?src-supplier=Element14; ComponentLink7URL: https://datasheet.ciiva.com/7850/1018594-7850079.pdf?src-supplier=Element14; ComponentLink8Description: https://datasheet.ciiva.com/16741/pyu-rc0402-51-rohs-l-6-r-16741018.pdf?src-supplier=Future+Electronics; ComponentLink8URL: https://datasheet.ciiva.com/16741/pyu-rc0402-51-rohs-l-6-r-16741018.pdf?src-supplier=Future+Electronics; Construction: Rectangular; Depth: 0.5mm; Features: Moisture Resistant; Height: 0.016inch; Lead Free: 5697LeadFree; Length: 0.039inch; Manufacturer Part Number: RC0402FR-076K8L; Max Operating Temperature: 155°C; Military Standard: Not; Min Operating Temperature: -55°C; Number of Terminations: 2; Package Quantity: 1; Packaging: Tape and Reel; Pins: 2; Power Rating: 63mW; Pricing 1: 10000=0.00261, 20000=0.00231, 30000=0.00216, 50000=0.00199, 100000=0.00179 (USD); Reach SVHC Compliant: No; Resistor Type: Thick Film; RoHS: Yes; RoHS Compliant: Yes; Series: RC; Stock 1: 627630; Supplier 1: Digikey; Supplier Part Number 1: 311-6.80KLRTR-ND; Technology: THICK FILM; Termination: SMD/SMT; Tolerance: 1%; Voltage Rating (AC): 50V; Voltage Rating (DC): 50V; Width: 0.02inch; Library Name: defcon_badge.SchLib
R27 R28 = 0402WGF100KTCE: 1 Ω, 0402 Chip resistor; house_part_number: R-1-0402-1%-001; Manufacturer: Uniroyal; Component Kind: Standard; Manufacturer Part Number: 0402WGF100KTCE; Pricing: 100=0.0008, 1000=0.0006, 3000=0.0005, 50000=0.0004 (USD); RoHS: No; Stock: 596300; Supplier: LCSC; Supplier Part Number 1: C25086; Library Name: defcon_badge.SchLib
R29 = RC0402FR-0790K9L: 90.9 kΩ, 0402 Chip resistor; Manufacturer: Yageo; Additional Feature: LASER TRIMMABLE; Package Height: 0.35mm; Package Length: 1mm; Package Width: 0.5mm; Power: 62.5mW; Rated Temperature: 70°C; Temperature Coefficient: 100ppm/°C; Tolerance: 1%; Working Voltage: 50V; Category: Chip SMD Resistors; Component Kind: Standard; ComponentLink1Description: https://datasheet.ciiva.com:443/pdfs/VipMasterIC/IC/YAGO/YAGO-S-A0008145938/YAGO-S-A0008145938-1.pdf?src-supplier=IHS+Markit; ComponentLink1URL: https://datasheet.ciiva.com:443/pdfs/VipMasterIC/IC/YAGO/YAGO-S-A0008145938/YAGO-S-A0008145938-1.pdf?src-supplier=IHS+Markit; ComponentLink2Description: https://datasheet.ciiva.com/26830/getdatasheetpartid-329194-26830263.pdf?src-supplier=Verical; ComponentLink2URL: https://datasheet.ciiva.com/26830/getdatasheetpartid-329194-26830263.pdf?src-supplier=Verical; ComponentLink3Description: https://datasheet.ciiva.com/12577/pyu-rc0402-51-rohs-l-6-r-12577455.pdf?src-supplier=Mouser; ComponentLink3URL: https://datasheet.ciiva.com/12577/pyu-rc0402-51-rohs-l-6-r-12577455.pdf?src-supplier=Mouser; Construction: Chip; JESD-609 Code: e3; Manufacturer Part Number: RC0402FR-0790K9L; Max Operating Temperature: 155°C; Min Operating Temperature: -55°C; Mounting Technology: Surface Mount; Package Shape: Rectangular; Package Style: SMTMeter; Packaging: Tape and Reel; Pins: 2; Pricing 1: 100=0.0006, 1000=0.0005, 3000=0.0004 (USD); Reach SVHC Compliant: Yes; Resistor Type: FIXED RESISTOR; RoHS: Yes; RoHS Compliant: Yes; Stock 1: 171300; Supplier 1: LCSC; Supplier Part Number 1: C163452; Technology: METAL GLAZE/THICK FILM; Terminal Finish: Nickel; Termination: Wraparound; Library Name: defcon_badge.SchLib
R30 R31 = RC0402FR-075K1L: 5.1 kΩ, 0402 Chip resistor; house_part_number: R-5.1k-0402-1%-001; Manufacturer: Yageo; Max Power Dissipation: 63mW; Resistance Tolerance: 1%; Temperature Coefficient: 100ppm/°C; Voltage Rating: 50V; Case Code (Metric): 1005; Case/Package: 0402; Category: Chip SMD Resistors; Component Kind: Standard; ComponentLink1Description: https://datasheet.ciiva.com/7983/1018594-7983519.pdf?src-supplier=Element14; ComponentLink1URL: https://datasheet.ciiva.com/7983/1018594-7983519.pdf?src-supplier=Element14; ComponentLink2Description: https://datasheet.ciiva.com/46394/1018594-46394936.pdf?src-supplier=Element14; ComponentLink2URL: https://datasheet.ciiva.com/46394/1018594-46394936.pdf?src-supplier=Element14; ComponentLink3Description: https://datasheet.ciiva.com/2453/pyu-r-int-thick-7-2453401.pdf?src-supplier=Digikey; ComponentLink3URL: https://datasheet.ciiva.com/2453/pyu-r-int-thick-7-2453401.pdf?src-supplier=Digikey; ComponentLink4Description: https://datasheet.ciiva.com/2453/pyu-r-int-thick-7-2453402.pdf?src-supplier=Digikey; ComponentLink4URL: https://datasheet.ciiva.com/2453/pyu-r-int-thick-7-2453402.pdf?src-supplier=Digikey; ComponentLink5Description: https://datasheet.ciiva.com/2453/pyu-r-int-thick-7-2453400.pdf?src-supplier=Digikey; ComponentLink5URL: https://datasheet.ciiva.com/2453/pyu-r-int-thick-7-2453400.pdf?src-supplier=Digikey; ComponentLink6Description: https://datasheet.ciiva.com/12558/pyu-rc0402-51-rohs-l-6-r-8721-12558460.pdf?src-supplier=Mouser; ComponentLink6URL: https://datasheet.ciiva.com/12558/pyu-rc0402-51-rohs-l-6-r-8721-12558460.pdf?src-supplier=Mouser; ComponentLink7Description: https://datasheet.ciiva.com/16744/pyu-rc0402-51-rohs-l-6-r-16744283.pdf?src-supplier=Future+Electronics; ComponentLink7URL: https://datasheet.ciiva.com/16744/pyu-rc0402-51-rohs-l-6-r-16744283.pdf?src-supplier=Future+Electronics; ComponentLink8Description: https://datasheet.ciiva.com/15189/getdatasheetpartid-323636-15189493.pdf?src-supplier=Verical; ComponentLink8URL: https://datasheet.ciiva.com/15189/getdatasheetpartid-323636-15189493.pdf?src-supplier=Verical; Construction: Rectangular; Depth: 0.5mm; Features: Moisture Resistant; Height: 0.016inch; Lead Free: 5697LeadFree; Length: 1mm; Manufacturer Part Number: RC0402FR-075K1L; Max Operating Temperature: 155°C; Military Standard: Not; Min Operating Temperature: -55°C; Number of Terminations: 2; Package Quantity: 1; Packaging: Tape and Reel; Power Rating: 63mW; Pricing: 10000=0.0024, 50000=0.0023, 250000=0.0021, 500000=0.0021, 1500000=0.0019 (USD); Reach SVHC Compliant: Unknown; Resistor Type: Thick Film; RoHS: Yes; RoHS Compliant: Yes; Series: RC; Stock: 1190000; Supplier 1: Future Electronics; Supplier Part Number 1: 6053649; Technology: THICK FILM; Termination: SMD/SMT; Tolerance: 1%; Voltage Rating (DC): 50V; Width: 0.02inch; Working Voltage: 50V; Library Name: defcon_badge.SchLib
R35 R36 = RC0402FR-07220RL: 220 Ω, 0402 Chip resistor; house_part_number: R-220-0402-1%-001; Manufacturer: Yageo; Max Power Dissipation: 63mW; Power Rating: 62.5mW; Resistance Tolerance: 1%; Temperature Coefficient: 100ppm/°C; Voltage Rating: 50V; Case Code (Metric): 1005; Case/Package: 0402; Category: Chip SMD Resistors; Component Kind: Standard; ComponentLink1Description: https://datasheet.ciiva.com/2453/pyu-r-int-thick-7-2453461.pdf?src-supplier=Digikey; ComponentLink1URL: https://datasheet.ciiva.com/2453/pyu-r-int-thick-7-2453461.pdf?src-supplier=Digikey; ComponentLink2Description: https://datasheet.ciiva.com/2453/pyu-r-int-thick-7-2453462.pdf?src-supplier=Digikey; ComponentLink2URL: https://datasheet.ciiva.com/2453/pyu-r-int-thick-7-2453462.pdf?src-supplier=Digikey; Construction: Rectangular; Depth: 0.5mm; Features: Moisture Resistant; Height: 0.016inch; Lead Free: 5697LeadFree; Length: 0.039inch; Manufacturer Part Number: RC0402FR-07220RL; Max Operating Temperature: 155°C; Military Standard: Not; Min Operating Temperature: -55°C; Number of Terminations: 2; Package Quantity: 1; Packaging: Tape and Reel; Pins: 2; Pricing: 10000=0.0012, 100000=0.0011, 300000=0.001, 750000=0.001, 2000000=0.0009 (USD); Reach SVHC Compliant: No; Resistor Type: Thick Film; RoHS: Yes; RoHS Compliant: Yes; Series: RC; Stock: 1390000; Supplier 1: Future Electronics; Supplier Part Number 1: 4696860; Technology: THICK FILM; Termination: SMD/SMT; Tolerance: 1%; Voltage Rating (AC): 50V; Voltage Rating (DC): 50V; Width: 0.02inch; Library Name: defcon_badge.SchLib
R43 = RC0402FR-07390KL: 390 kΩ, 0402 Chip resistor; Manufacturer: Yageo; Category: Chip SMD Resistors; Component Kind: Standard; ComponentLink1Description: https://datasheet.ciiva.com/7849/1018594-7849976.pdf?src-supplier=Element14; ComponentLink1URL: https://datasheet.ciiva.com/7849/1018594-7849976.pdf?src-supplier=Element14; ComponentLink2Description: https://datasheet.ciiva.com/7849/1018594-7849977.pdf?src-supplier=Element14; ComponentLink2URL: https://datasheet.ciiva.com/7849/1018594-7849977.pdf?src-supplier=Element14; ComponentLink3Description: https://datasheet.ciiva.com/16741/pyu-rc0402-51-rohs-l-6-16741911.pdf?src-supplier=Future+Electronics; ComponentLink3URL: https://datasheet.ciiva.com/16741/pyu-rc0402-51-rohs-l-6-16741911.pdf?src-supplier=Future+Electronics; ComponentLink4Description: https://datasheet.ciiva.com/12577/pyu-rc0402-51-rohs-l-6-r-12577727.pdf?src-supplier=Mouser; ComponentLink4URL: https://datasheet.ciiva.com/12577/pyu-rc0402-51-rohs-l-6-r-12577727.pdf?src-supplier=Mouser; Manufacturer Part Number: RC0402FR-07390KL; Pricing 1: 100=0.0006, 1000=0.0005, 3000=0.0004 (USD); RoHS: Yes; Stock 1: 54700; Supplier 1: LCSC; Supplier Part Number 1: C163467; Library Name: defcon_badge.SchLib
SW12 = TSC015A08026B: Tactile switch, SPST; house_part_number: SW-TAC-4.5-8-260gf; Actuator Type: Standard; Illumination Type: Non-Illuminated; Mechanical Life: 100000Cycles; Operating Force: 260gf; Ratings: IP40; Switch Function: Off-Mom; Switch Travel: 0.25mm; Category: Tactile Switches; Component Kind: Standard; ComponentLink1Description: https://www.ckswitches.com/media/2567/pts647.pdf?src-supplier=Digi-Key; ComponentLink1URL: https://www.ckswitches.com/media/2567/pts647.pdf?src-supplier=Digi-Key; Height: 8mm; Manufacturer Part Number: TSC015A08026B; Max Operating Temperature: 70°C; Min Operating Temperature: -20°C; Mount: Surface Mount; Packaging: Tape and Reel; Pricing 1: 1=0.13000, 10=0.12700, 25=0.12080, 100=0.10970, 250=0.10308, 500=0.09432, 1000=0.07897 (USD); RoHS: No; Stock 1: 68566; Termination: Gull Wing; Throw Configuration: SPST; Library Name: defcon_badge.SchLib
TP48-TP57 = test_point-1: Test point; pickable: no; Library Name: defcon_badge.SchLib
U8 = TLV62569DBVR: Buck converter, 2.5-5.5 Vin, 0.6 - 5.5 Vout, 2A, SOT-23-5; Manufacturer: Texas Instruments; Max Output Voltage: 5.5V; Min Input Voltage: 2.5V; Min Output Voltage: 600mV; Output Configuration: Positive; Output Type: Adjustable; Switching Frequency: 1.5MHz; Synchronous Rectifier: Yes; Category: Voltage Regulators - Switching; Component Kind: Standard; ComponentLink1Description: http://www.ti.com/lit/ds/symlink/tlv62569.pdf?src-supplier=Digikey; ComponentLink1URL: http://www.ti.com/lit/ds/symlink/tlv62569.pdf?src-supplier=Digikey; ComponentLink2Description: http://www.ti.com/lit/ds/symlink/tlv62569.pdf?src-supplier=Farnell; ComponentLink2URL: http://www.ti.com/lit/ds/symlink/tlv62569.pdf?src-supplier=Farnell; house_part_number: TLV62569DBVR; Manufacturer Part Number: TLV62569DBVR; Max Operating Temperature: 125°C; Max Supply Voltage: 5.5V; Min Operating Temperature: -40°C; Mounting Technology: Surface Mount; Output Current: 2A; Packaging: Cut Tape; Pricing: 1=0.45, 10=0.344, 50=0.344, 100=0.214, 1000=0.113, 10000=0.087 (USD); RoHS: Yes; RoHS Compliant: Yes; Series: -; Stock: 12579; Supplier: Mouser; Supplier 1: LCSC; Supplier Part Number: 595-TLV62569DBVR; Supplier Part Number 1: C141836; Library Name: defcon_badge.SchLib
U9 = LGS4056H: Battery Charger, DFN-8(2x2); Case/Package: DFN-8(2x2); Component Kind: Standard; Manufacturer Part Number: LGS4056HDA; Supplier 1: LCSC; Supplier Part Number 1: C5124109; Library Name: defcon_badge.SchLib
U10 = SRV05-4A: TVS DIODE ARRAY SOT23-6; Manufacturer: SMC Diodes; Breakdown Voltage: 6V; Capacitance: 3.5pF; Clamping Voltage: 17V; Data Rate: 480Mbps; Direction: Unidirectional,Bidirectional; Element Configuration: Dual; ESD Protection: Yes; Leakage Current: 150nA; Number of Bidirectional Channels: 2; Number of Elements: 1; Operating Supply Voltage: 1.1V; Peak Pulse Current: 5A; Reverse Standoff Voltage: 5.25V; Test Current: 1mA; Working Voltage: 5V; Category: TVS Diodes; Clamping Voltage-Max: 17V; Component Kind: Standard; ComponentLink1Description: https://datasheet.ciiva.com/1268/1690354-1268384.pdf?src-supplier=Element14; ComponentLink1URL: https://datasheet.ciiva.com/1268/1690354-1268384.pdf?src-supplier=Element14; ComponentLink2Description: https://datasheet.ciiva.com/4508/cd00050750-113117-4508433.pdf?src-supplier=Mouser; ComponentLink2URL: https://datasheet.ciiva.com/4508/cd00050750-113117-4508433.pdf?src-supplier=Mouser; ComponentLink3Description: https://datasheet.ciiva.com/15143/getdatasheetpartid-148465-15143789.pdf?src-supplier=Verical; ComponentLink3URL: https://datasheet.ciiva.com/15143/getdatasheetpartid-148465-15143789.pdf?src-supplier=Verical; ComponentLink4Description: https://datasheet.ciiva.com/16200/cd00050750-16200026.pdf?src-supplier=Future+Electronics; ComponentLink4URL: https://datasheet.ciiva.com/16200/cd00050750-16200026.pdf?src-supplier=Future+Electronics; ComponentLink5Description: https://datasheet.ciiva.com/41444/001184109-da-01-en-ic-esd-protecti-usblc6-2sc6-sot-23-6-stm-41444156.pdf?src-supplier=Conrad; ComponentLink5URL: https://datasheet.ciiva.com/41444/001184109-da-01-en-ic-esd-protecti-usblc6-2sc6-sot-23-6-stm-41444156.pdf?src-supplier=Conrad; Contact Plating: Tin,Matte; Depth: 1.75mm; Height: 1.3mm; house_part_number: SRV05-4A; Lead Free: Lead Free; Length: 3.05mm; Manufacturer Part Number: SRV05-4A; Max Breakdown Voltage: 6V; Max Operating Temperature: 125°C; Max Reverse Leakage Current: 150nA; Min Breakdown Voltage: 6V; Min Operating Temperature: -40°C; Mount: Surface Mount; Number of Channels: 2; Number of Pins: 6; Package Quantity: 3000; Packaging: Tape and Reel; Polarity: Unidirectional,Bidirectional; Power Line Protection: Yes; Pricing: 3000=0,05640 (USD); Radiation Hardening: No; REACH SVHC: No SVHC; Reverse Breakdown Voltage: 6V; RoHS: Yes; RoHS Compliant: Yes; Stock: 12000; Supplier 1: LCSC; Supplier Part Number 1: C260410; Termination: SMD/SMT; Width: 1.75mm; Library Name: defcon_badge.SchLib
